# Altium schematic sheet Sheet2.SchDoc
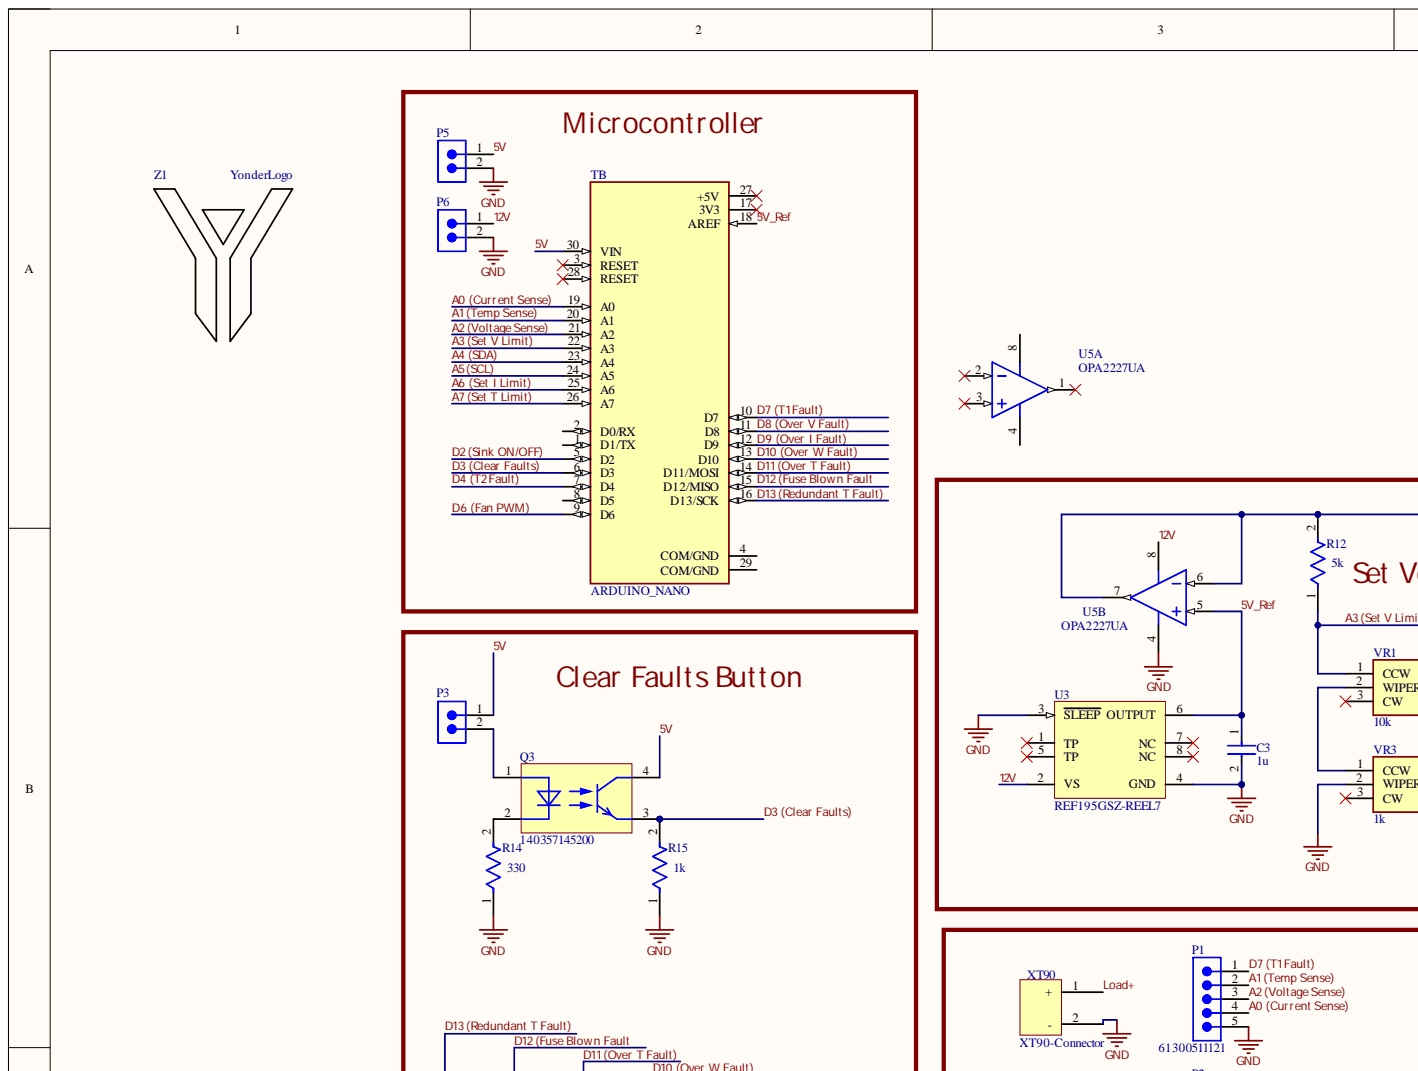
[diagram p0: Sheet2.SchDoc, top left]
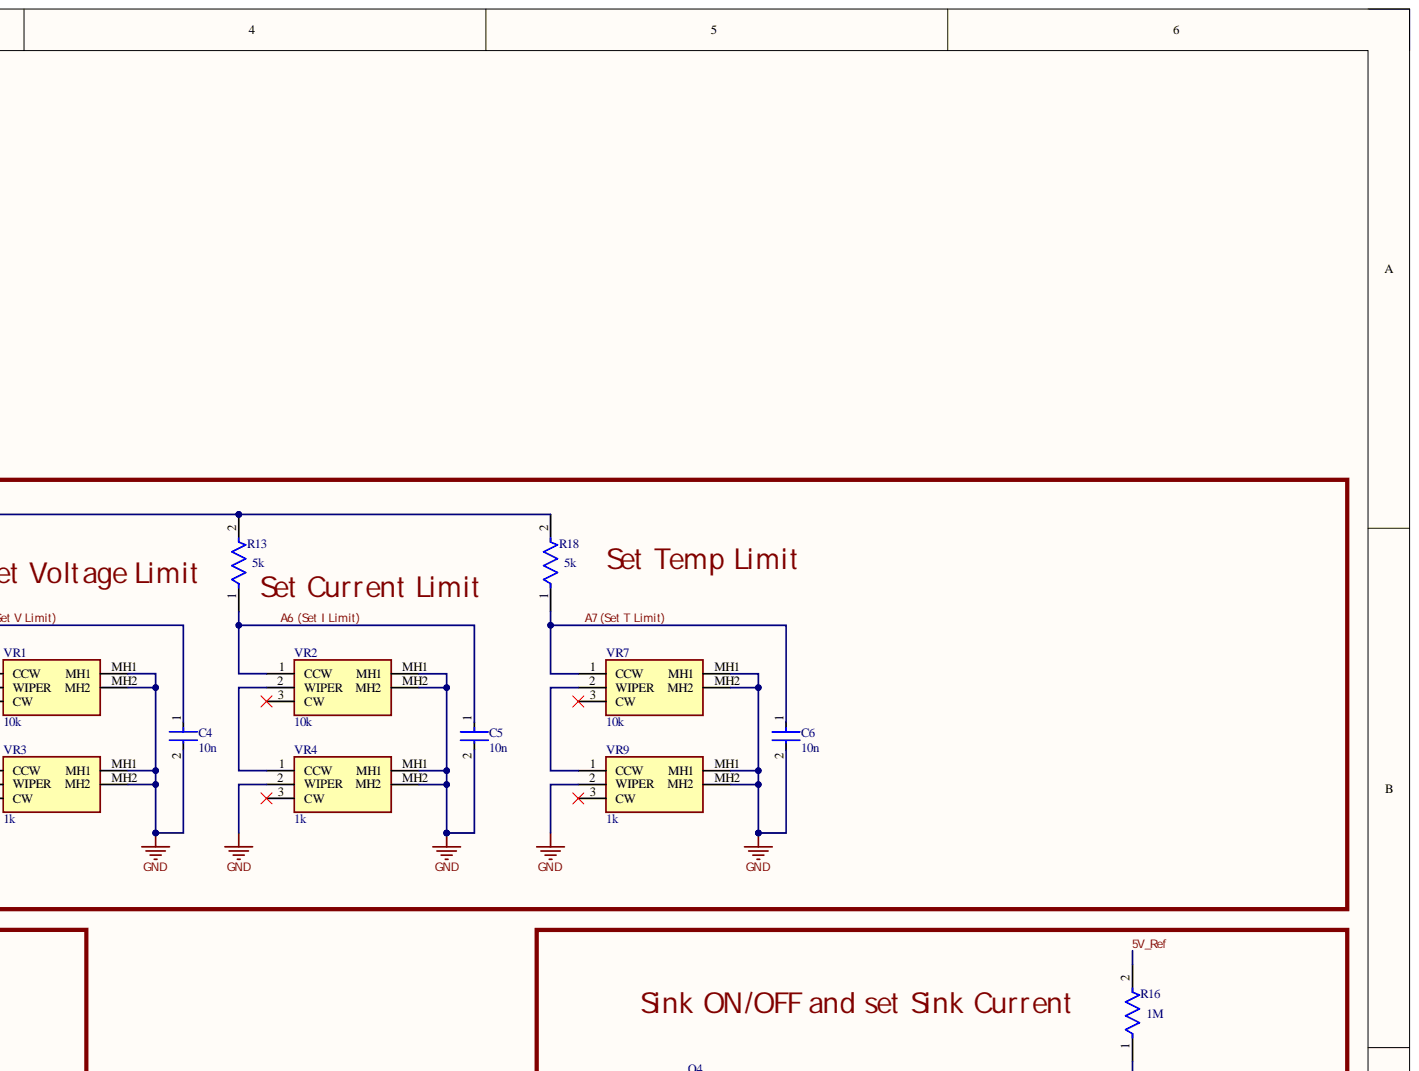
[diagram p1: Sheet2.SchDoc, top right]
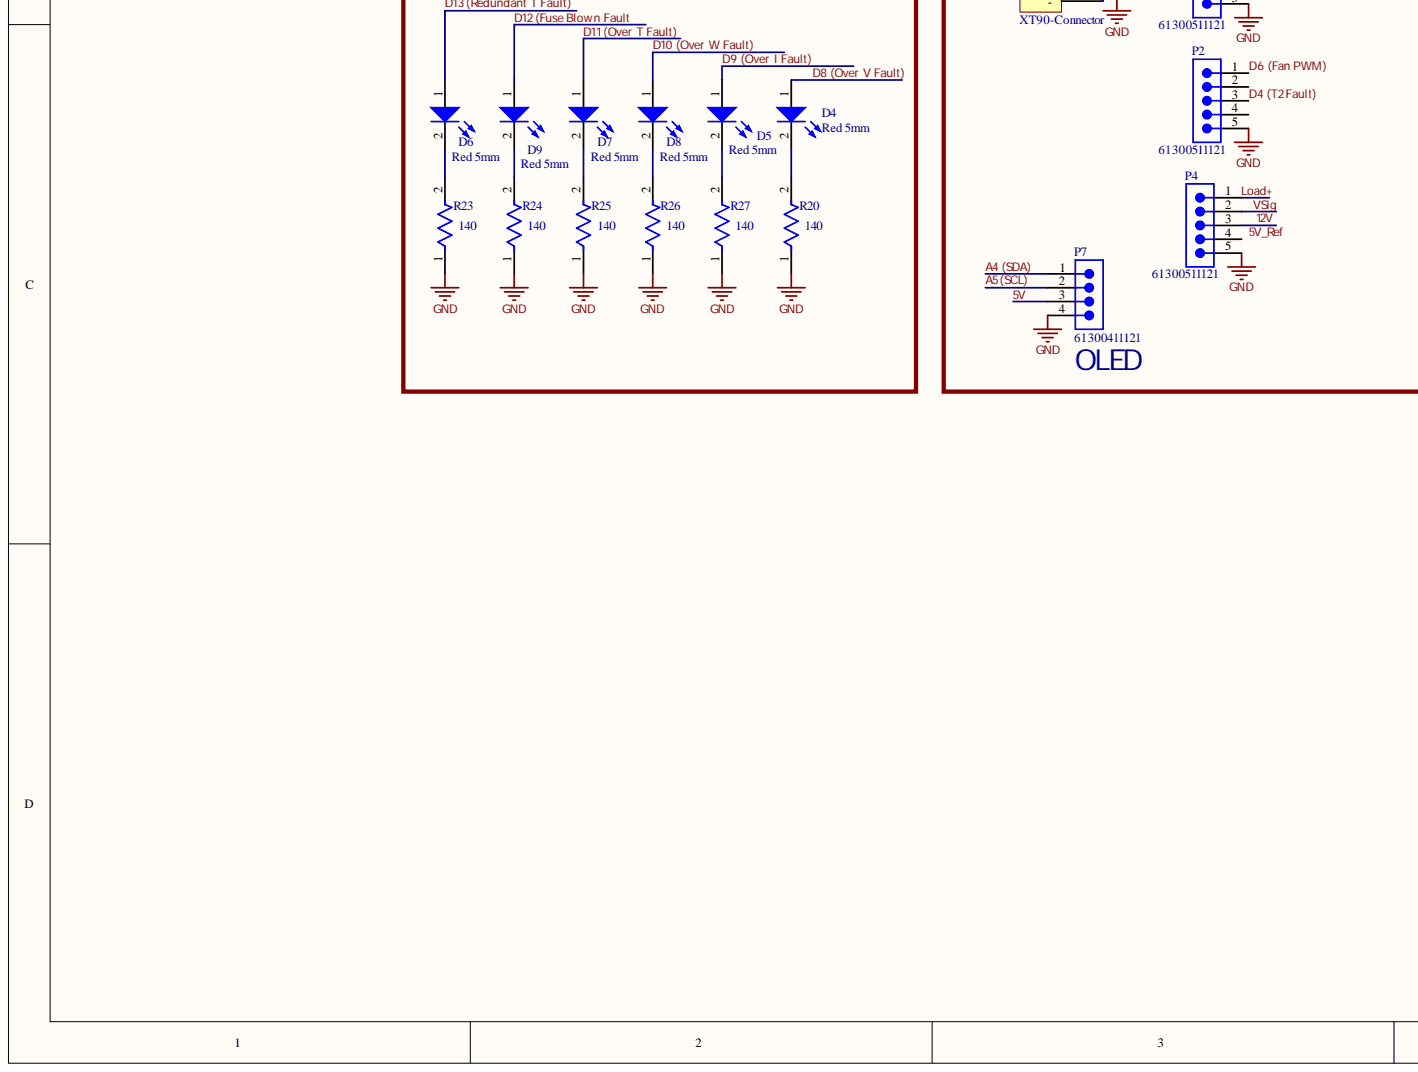
[diagram p2: Sheet2.SchDoc, bottom left]
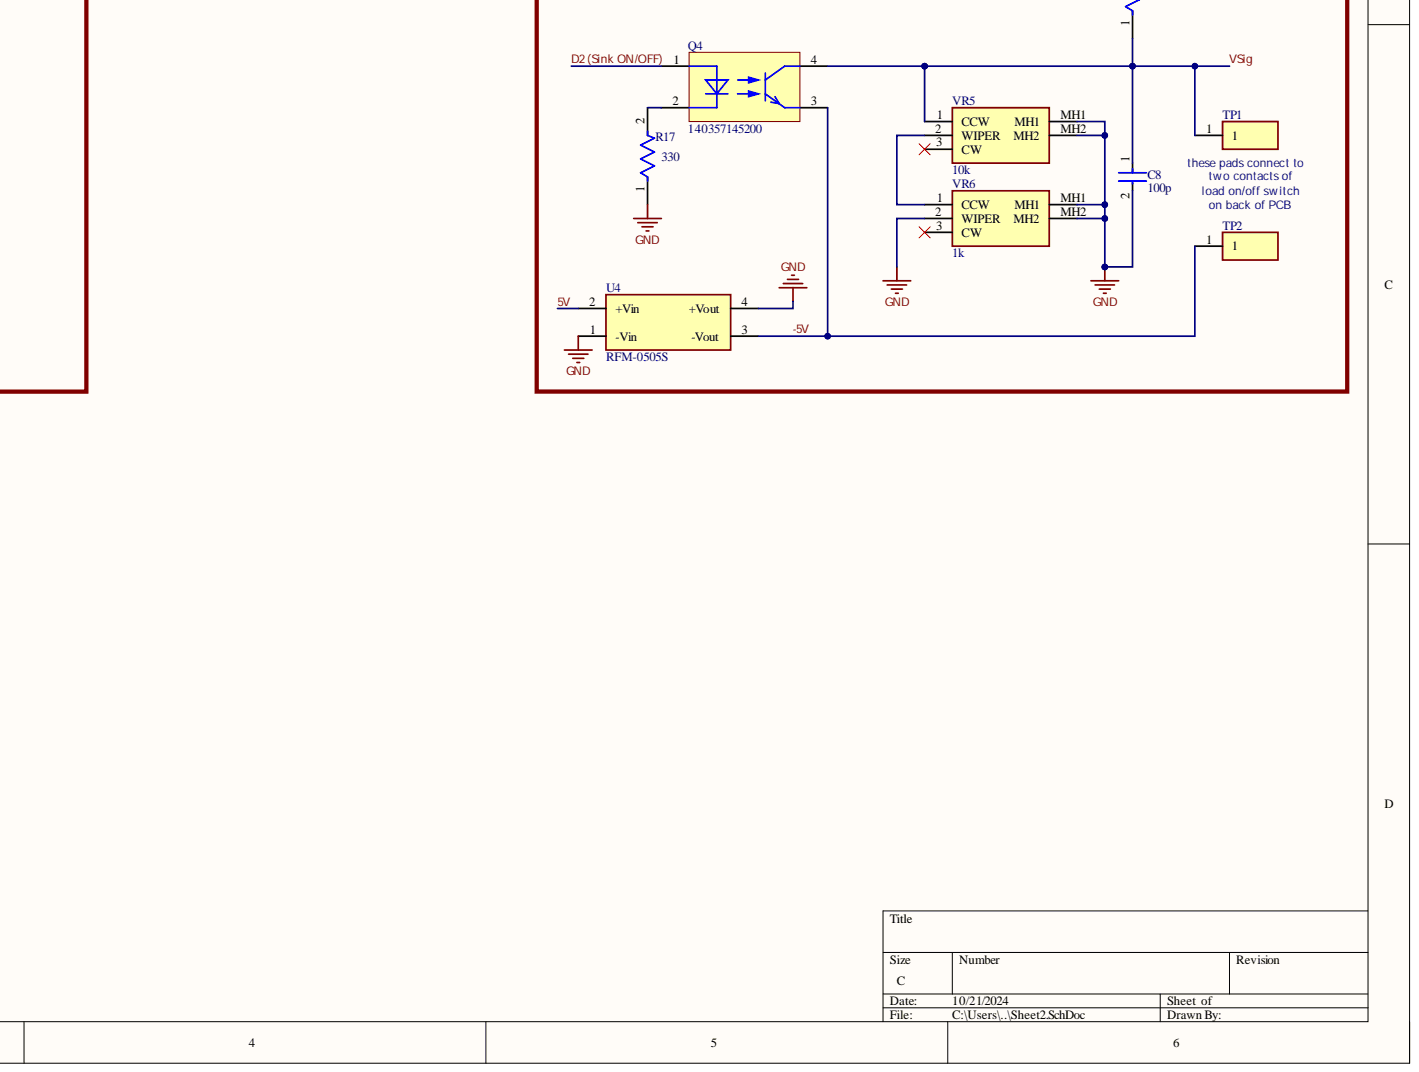
[diagram p3: Sheet2.SchDoc, bottom right]

## Parts
*XT1  XT90-Connector  [XT90-Footprint-1]
C3  1u  [FP-C1206C-EB-MFG]
C4  10n  [FP-C1206C-EB-MFG]
C5  10n  [FP-C1206C-EB-MFG]
C6  10n  [FP-C1206C-EB-MFG]
C8  100p  [FP-C1206C-EB-MFG]
D4  Red 5mm  Length: 5mm  [WL-TMRC_D5.9H8.7]
D5  Red 5mm  Length: 5mm  [WL-TMRC_D5.9H8.7]
D6  Red 5mm  Length: 5mm  [WL-TMRC_D5.9H8.7]
D7  Red 5mm  Length: 5mm  [WL-TMRC_D5.9H8.7]
D8  Red 5mm  Length: 5mm  [WL-TMRC_D5.9H8.7]
D9  Red 5mm  Length: 5mm  [WL-TMRC_D5.9H8.7]
P1  61300511121  [61300511121]
P2  61300511121  [61300511121]
P3  61300211121  [61300211121]  (value not drawn)
P4  61300511121  [61300511121]
P5  61300211121  [61300211121]  (value not drawn)
P6  61300211121  [61300211121]  (value not drawn)
P7  61300411121  [61300411121]
Q3  140357145200  [WL-OCPT_SOP4]
Q4  140357145200  [WL-OCPT_SOP4]
R12  5k  [FP-RC1206-MFG]
R13  5k  [FP-RC1206-MFG]
R14  330  [FP-RC1206-MFG]
R15  1k  [FP-RC1206-MFG]
R16  1M  [FP-RC1206-MFG]
R17  330  [FP-RC1206-MFG]
R18  5k  [FP-RC1206-MFG]
R20  140  [FP-RC1206-MFG]
R23  140  [FP-RC1206-MFG]
R24  140  [FP-RC1206-MFG]
R25  140  [FP-RC1206-MFG]
R26  140  [FP-RC1206-MFG]
R27  140  [FP-RC1206-MFG]
TB  ARDUINO_NANO  [SHIELD_ARDUINO_NANO]
TP1  RCT-0C  [RCT-0C]  (value not drawn)
TP2  RCT-0C  [RCT-0C]  (value not drawn)
U3  REF195GSZ-REEL7  [ADI-R-8_L]
U4  RFM-0505S  Max Output Voltage: 5V  [FP-RFM-0505S-MFG]
U5  OPA2227UA  [D0008A_N]  (parts A,B)
VR1  10k  [PTV09A4015UB102]
VR2  10k  [PTV09A4015UB102]
VR3  1k  [PTV09A4015UB102]
VR4  1k  [PTV09A4015UB102]
VR5  10k  [PTV09A4015UB102]
VR6  1k  [PTV09A4015UB102]
VR7  10k  [PTV09A4015UB102]
VR9  1k  [PTV09A4015UB102]
Z1  YonderLogo  [PCBLogo500mil]

## Nets
GND: *XT1.2 C3.2 C4.2 C5.2 C6.2 C8.2 P1.5 P2.5 P4.5 P5.2 P6.2 P7.4 R14.1 R15.1 R17.1 R20.1 R23.1 R24.1 R25.1 R26.1 R27.1 U3.3(SLEEP) U3.4(GND) U4.1(-Vin) U4.4(+Vout) U5.4 VR1.MH1 VR1.MH2 VR2.MH1 VR2.MH2 VR3.2(WIPER) VR3.MH1 VR3.MH2 VR4.2(WIPER) VR4.MH1 VR4.MH2 VR5.MH1 VR5.MH2 VR6.2(WIPER) VR6.MH1 VR6.MH2 VR7.MH1 VR7.MH2 VR9.2(WIPER) VR9.MH1 VR9.MH2
5V: P3.1 P5.1 P7.3 Q3.4 TB.30(VIN) U4.2(+Vin)
5V_Ref: C3.1 R16.2 TB.18(AREF) U3.6(OUTPUT) U5.5
12V: P4.3 P6.1 U3.2(VS) U5.8
-5V: Q4.3 TP2.1 U4.3(-Vout)
A0 (Current Sense): P1.4 TB.19(A0)
A1 (Temp Sense): P1.2 TB.20(A1)
A2 (Voltage Sense): P1.3 TB.21(A2)
A3 (Set V Limit): C4.1 R12.1 TB.22(A3) VR1.1(CCW)
A4 (SDA): P7.1 TB.23(A4)
A5 (SCL): P7.2 TB.24(A5)
A6 (Set I Limit): C5.1 R13.1 TB.25(A6) VR2.1(CCW)
A7 (Set T Limit): C6.1 R18.1 TB.26(A7) VR7.1(CCW)
D2 (Sink ON/OFF): Q4.1 TB.5(D2)
D3 (Clear Faults): Q3.3 R15.2 TB.6(D3)
D4 (T2 Fault): P2.3 TB.7(D4)
D6 (Fan PWM): P2.1 TB.9(D6)
D7 (T1 Fault): P1.1 TB.10(D7)
D8 (Over V Fault): D4.1 TB.11(D8)
D9 (Over I Fault): D5.1 TB.12(D9)
D10 (Over W Fault): D8.1 TB.13(D10)
D11 (Over T Fault): D7.1 TB.14(D11/MOSI)
D12 (Fuse Blown Fault: D9.1 TB.15(D12/MISO)
D13 (Redundant T Fault): D6.1 TB.16(D13/SCK)
Load+: *XT1.1 P4.1
VSig: C8.1 P4.2 Q4.4 R16.1 TP1.1 VR5.1(CCW)
NetD4_2: D4.2 R20.2
NetD5_2: D5.2 R27.2
NetD6_2: D6.2 R23.2
NetD7_2: D7.2 R25.2
NetD8_2: D8.2 R26.2
NetD9_2: D9.2 R24.2
NetP3_2: P3.2 Q3.1
NetQ3_2: Q3.2 R14.2
NetQ4_2: Q4.2 R17.2
NetR12_2: R12.2 R13.2 R18.2 U5.6 U5.7
NetVR1_2: VR1.2(WIPER) VR3.1(CCW)
NetVR2_2: VR2.2(WIPER) VR4.1(CCW)
NetVR5_2: VR5.2(WIPER) VR6.1(CCW)
NetVR7_2: VR7.2(WIPER) VR9.1(CCW)
Clear Faults Button:
Microcontroller:
Set Current Limit:
Set Temp Limit:
Set Voltage Limit:
Sink ON/OFF and set Sink Current:

Not connected: P2 2 4; P4 4; TB 1(D1/TX) 2(D0/RX) 3(RESET) 4(COM/GND) 8(D5) 17(3V3) 27(+5V) 28(RESET) 29(COM/GND); U3 1(TP) 5(TP) 7(NC) 8(NC); U5 1 2 3; VR1 3(CW); VR2 3(CW); VR3 3(CW); VR4 3(CW); VR5 3(CW); VR6 3(CW); VR7 3(CW); VR9 3(CW)

## Library data of the parts
*XT1 = XT90-2: XT90 connector for vertical PCB mounting; Component Kind: Standard; Library Name: Yonder Dynamics
C3-C6 C8 = CMP-03020-000153-1: CAP CER 0.1UF 100V X7R 1206; Manufacturer: KEMET; Manufacturer Part Number: C1206C104K1RACTU; Automotive Grade: Grade 0; Capacitance: 0.10 μF; Material: Ceramic; Temperature Coefficient: 15%; Tolerance: 0.1; Value: 0.10 μF; Automotive: Yes; Category: Cap; Component Kind: Standard; ComponentLink2Description: Datasheet; ComponentLink2URL: https://content.kemet.com/datasheets/KEM_C1007_X8R_ULTRA_150C_SMD.pdf; Device Class L1: Passive Components; Device Class L2: Capacitors; Device Class L3: Ceramic Capacitors; Digikey Description: CAP CER 0.1UF 100V X7R 1206; Height: 0.88mm; Ipc Land Pattern Name: CAPC320160X78; Lead Free: Yes; Library Name: Altium Content Vault; Mouser Description: CAP CER 0.1UF 100V X7R 1206; Octopart: https://octopart.com/c1206c104k1ractu-kemet-89521; Package: C1206C-EB; RoHS: TRUE; Temperature: -55°C to +150°C; Temperature Characteristic: X7R; Temperature Range High: +150°C; Temperature Range Low: -55°C; Voltage Rating: 100 V
D4-D9 = CMP-1488-00009-2: NexarPartId: 29717812; Color: Blue; Dominant Wavelength: 470nm; Forward Current: 100mA; Forward Voltage: 3.2V; Lens Style: Circular, Diffused, Round; Luminous Intensity: 500mcd; Max Reverse Voltage (DC): 5V; Peak Wavelength: 465nm; Test Current: 20mA; Viewing Angle: 40°; Case/Package: Radial; Component Kind: Standard; Height: 8.6mm; Lens Size: 5mm; Library Name: Altium Content Vault; Max Operating Temperature: 85°C; Min Operating Temperature: -40°C; Mount: Through Hole; Packaging: Bulk; REACH SVHC: Unknown; RoHS: Compliant; Wavelength: 470nm; Width: 5mm
P1 P2 P4 = CMP-1502-01068-3: NexarPartId: 32855526; Connector Type: Male Pin; Contact Resistance: 20mΩ; Current Rating: 3A; Gender: Male; Number of Contacts: 5; Operating Supply Voltage: 250V; Pitch: 2.54mm; Color: Black; Component Kind: Standard; Contact Material: Copper; Contact Mating Length: 5.9944mm; Contact Plating: Gold; Height: 8.89mm; Lead Free: Lead Free; Lead Pitch: 2.54mm; Library Name: Altium Content Vault; Max Operating Temperature: 105°C; Min Operating Temperature: -40°C; Mount: Through Hole; Number of Pins: 5; Number of Positions: 5; Number of Rows: 1; Orientation: Vertical; Packaging: Bulk; REACH SVHC: Unknown; RoHS: Compliant; Termination: Solder; Voltage Rating: 250V
P3 P5 P6 = CMP-1712-00002-6: NexarPartId: 20081794; Connector Type: Male Pin; Contact Resistance: 20mΩ; Current Rating: 3A; Gender: Male; Number of Contacts: 2; Operating Supply Voltage: 250V; Pitch: 2.54mm; Color: Black; Component Kind: Standard; Contact Gender: Male; Contact Material: Copper; Contact Mating Length: 5.9944mm; Contact Plating: Gold; Height: 8.94mm; Housing Material: PBT; Lead Free: Lead Free; Lead Pitch: 2.54mm; Library Name: Altium Content Vault; Max Operating Temperature: 125°C; Min Operating Temperature: -40°C; Mount: Through Hole; Number of Pins: 2; Number of Positions: 2; Number of Rows: 1; Orientation: Vertical; Packaging: Bulk; REACH SVHC: No SVHC; RoHS: Compliant; Termination: Through Hole; Voltage Rating: 250V
P7 = CMP-1502-01064-3: NexarPartId: 32855522; Connector Type: Male Pin; Contact Resistance: 20mΩ; Current Rating: 3A; Gender: Male; Number of Contacts: 4; Operating Supply Voltage: 250V; Pitch: 2.54mm; Color: Black; Component Kind: Standard; Contact Material: Copper; Contact Mating Length: 5.9944mm; Contact Plating: Gold; Height: 8.89mm; Lead Free: Lead Free; Lead Pitch: 2.54mm; Library Name: Altium Content Vault; Max Operating Temperature: 105°C; Min Operating Temperature: -40°C; Mount: Through Hole; Number of Pins: 4; Number of Positions: 4; Number of Rows: 1; Orientation: Vertical; Packaging: Bulk; REACH SVHC: Unknown; RoHS: Compliant; Termination: Solder; Voltage Rating: 250V
Q3 Q4 = CMP-03913-114060673-1: 140357145200 Optocoupler, Transistor, 35V, Sop-4 Rohs Compliant: Yes; Forward Current: 60mA; Forward Voltage: 1.24V; Isolation Voltage: 3.75kV; Max Reverse Voltage (DC): 6V; Component Kind: Standard; Height: 2mm; Length: 4.4mm; Library Name: Altium Content Vault; Max Operating Temperature: 110°C; Min Operating Temperature: -55°C; NexarPartId: 114060673; RoHS: Compliant; Width: 3.6mm
R12-R18 R20 R23-R27 = CMP-2100-03681-2: RES SMD 0 OHM JUMPER 1/4W 1206; Manufacturer: YAGEO; Manufacturer Part Number: RC1206JR-070RL; Power Rating: 0.25W; Resistance: 0Ω; Temperature Coefficient: 300ppm/°C; Tolerance: 5%; Value: 0Ω; Voltage Rating: 200V; Automotive: No; Category: Res; Component Kind: Standard; ComponentLink2Description: Datasheet; ComponentLink2URL: https://www.yageo.com/upload/media/product/productsearch/datasheet/rchip/PYu-RC_Group_51_RoHS_L_10.pdf; Composition: Thick Film; Device Class L1: Passive Components; Device Class L2: Resistors; Device Class L3: Chip SMD Resistors; Digikey Description: RES SMD 0 OHM JUMPER 1/4W 1206; Footprint Url: https://www.yageo.com/upload/website/yageo_PYu-R_Mount_10_19050818_343.pdf; Height: 0.65mm; Ipc Land Pattern Name: RESC310160X55; Lead Free: Yes; Library Name: Altium Content Vault; Mouser Description: Resistor: thick film; SMD; 1206; 0O; 0.25W; ±5%; -55÷155°C; Octopart: https://octopart.com/rc1206jr-070rl-yageo-40025601; Package: RC1206; RoHS: TRUE; Series: RC; Temperature: -55°C to +155°C; Temperature Range High: +155°C; Temperature Range Low: -55°C
TB = CMP-005-000003-2: ATSAMD21G18A Arduino Nano 33 IoT SAM D ARMÂ® CortexÂ®-M0+ MCU 32-Bit Embedded Evaluation Board; Availability: Unavailable; Component Kind: Standard; Design Item ID: ARDUINO_NANO; MF: Arduino; MP: ARDUINO NANO; Package: None; Price: None; SnapEDA_Link: https://www.snapeda.com/parts/ARDUINO%20NANO/Arduino/view-part/1128261/?ref=snap; Library Name: Yonder Dynamics; Source: MainEnclosure.SchLib
TP1 TP2 = RCT-0C: Test Point; Manufacturer_Name: TE Connectivity; Arrow Part Number: RCT-0C; Arrow Price/Stock: https://www.arrow.com/en/products/rct-0c/te-connectivity?region=nac; Component Kind: Standard; Datasheet Link: https://www.te.com/commerce/DocumentDelivery/DDEController?Action=srchrtrv&DocNm=1773266&DocType=Data%20Sheet&DocLang=English&PartCntxt=1625854-2&DocFormat=pdf; Manufacturer_Part_Number: RCT-0C; Mouser Part Number: 279-RCT-0C; Mouser Price/Stock: https://www.mouser.co.uk/ProductDetail/TE-Connectivity-Holsworthy/RCT-0C?qs=ip69W3eHERWzZOsRKmFYAw%3D%3D
U3 = CMP-0784-00326-1: Precision Micropower, Low Dropout Voltage Reference, 5 V Output, 5.1 to 15 V Supply, Industrial, 8-pin SOIC (R-8), Reel; Manufacturer: Analog Devices; Ref Out TC (ppm/C): 10; Vout: 5V; Code_JEDEC: MS-012-AA; Component Kind: Standard; ComponentLink1Description: Manufacturer URL; ComponentLink1URL: http://www.analog.com/en/index.html; ComponentLink2Description: Datasheet; ComponentLink2URL: http://www.analog.com/static/imported-files/data_sheets/REF19xSeries.pdf; ComponentLink3Description: Package Specification; ComponentLink3URL: http://www.analog.com/static/imported-files/packages/PKG_PDF/SOIC_Narrow%20(R)/R_8.pdf; ComponentLink4Description: URL Link1; ComponentLink4URL: Product URL:http://www.analog.com/en/special-linear-functions/voltage-references/ref195/products/product.html; DatasheetVersion: Rev. L; Library Name: Altium Content Vault; Mounting Technology: Surface Mount; Operating Temp Range: -40 to 85 degC; PackageDescription: 8-Pin Standard Small Outline Package, Body 4.9 x 3.9 mm, Pitch 1.27 mm; PackageReference: R-8; PackageVersion: 060506-A, 06/2006; Packing: Reel; PartNumber: REF195GSZ-REEL7
U4 = CMP-29842-000017-1: DC DC CONVERTER 5V 1W; Component Kind: Standard; Height: 10mm; Length: 11.5mm; Library Name: Altium Content Vault; Weight: 1.4g; Width: 6mm
U5 = CMP-0272-00265-3: High Precision, Low Noise Operational Amplifier, 5 to 36 V, -40 to 85 degC, 8-pin SOIC (D8), Green (RoHS & no Sb/Br); Manufacturer: Texas Instruments; CMRR(Min)(dB): 120; GBW(Typ)(MHz): 8; IIB(Max)(pA): 10000; Iq per channel(Max)(mA): 3.8; Number of Channels: 2; Offset Drift(Typ)(uV/C): 0.3; Packing: TUBE; Rating: Catalog; Slew Rate(Typ)(V/us): 2.3; Sub Family: High Supply Voltage Amplifier (>=30V), Low Noise Amp (<=10nV/rtHz), Low Offset Voltage Amplifier (<=500uV), Precision Amplifier; Total Supply Voltage(Max)(+5V is 5, +/-5V is 10): 36; Total Supply Voltage(Min)(+5V is 5, +/-5V is 10): 5; Vio (25C)(Max)(mV): 0.2; Code_JEDEC: MS-012; Component Kind: Standard; ComponentLink1Description: Manufacturer URL; ComponentLink1URL: http://www.ti.com/; ComponentLink2Description: Datasheet; ComponentLink2URL: http://www.ti.com/lit/ds/symlink/opa2227.pdf; ComponentLink3Description: Package Specification; ComponentLink3URL: http://www.ti.com/litv/pdf/msoi002j; DatasheetVersion: SBOS110A; Eco-Plan: Green (RoHS & no Sb/Br); Library Name: Altium Content Vault; Mounting Technology: Surface Mount; PackageDescription: 8-Pin Plastic Small Outline, Body 4.9 x 3.9 mm, Pitch 1.27 mm; PackageReference: D0008A; PackageVersion: 4040047-3/M, 06/2011; PartNumber: OPA2227UA
VR1-VR7 VR9 = PTV09A-4015U-B102: Variable Resistor; Manufacturer_Name: Bourns; Component Kind: Standard; Datasheet Link: https://www.bourns.com/docs/Product-Datasheets/PTV09.pdf; Height: 15.5mm; Manufacturer_Part_Number: PTV09A-4015U-B102; Mouser Part Number: 652-PTV09A-4015UB102; Mouser Price/Stock: https://www.mouser.co.uk/ProductDetail/Bourns/PTV09A-4015U-B102?qs=pxDZlBjcsCgsHUsGGnkp9g%3D%3D
Z1 = CMP-005-000005-1: Component Kind: Standard; Design Item ID: YonderLogo; Library Name: Yonder Dynamics; Source: MainEnclosure.SchLib
